# Altium schematic sheet MCU.SchDoc(MCU)
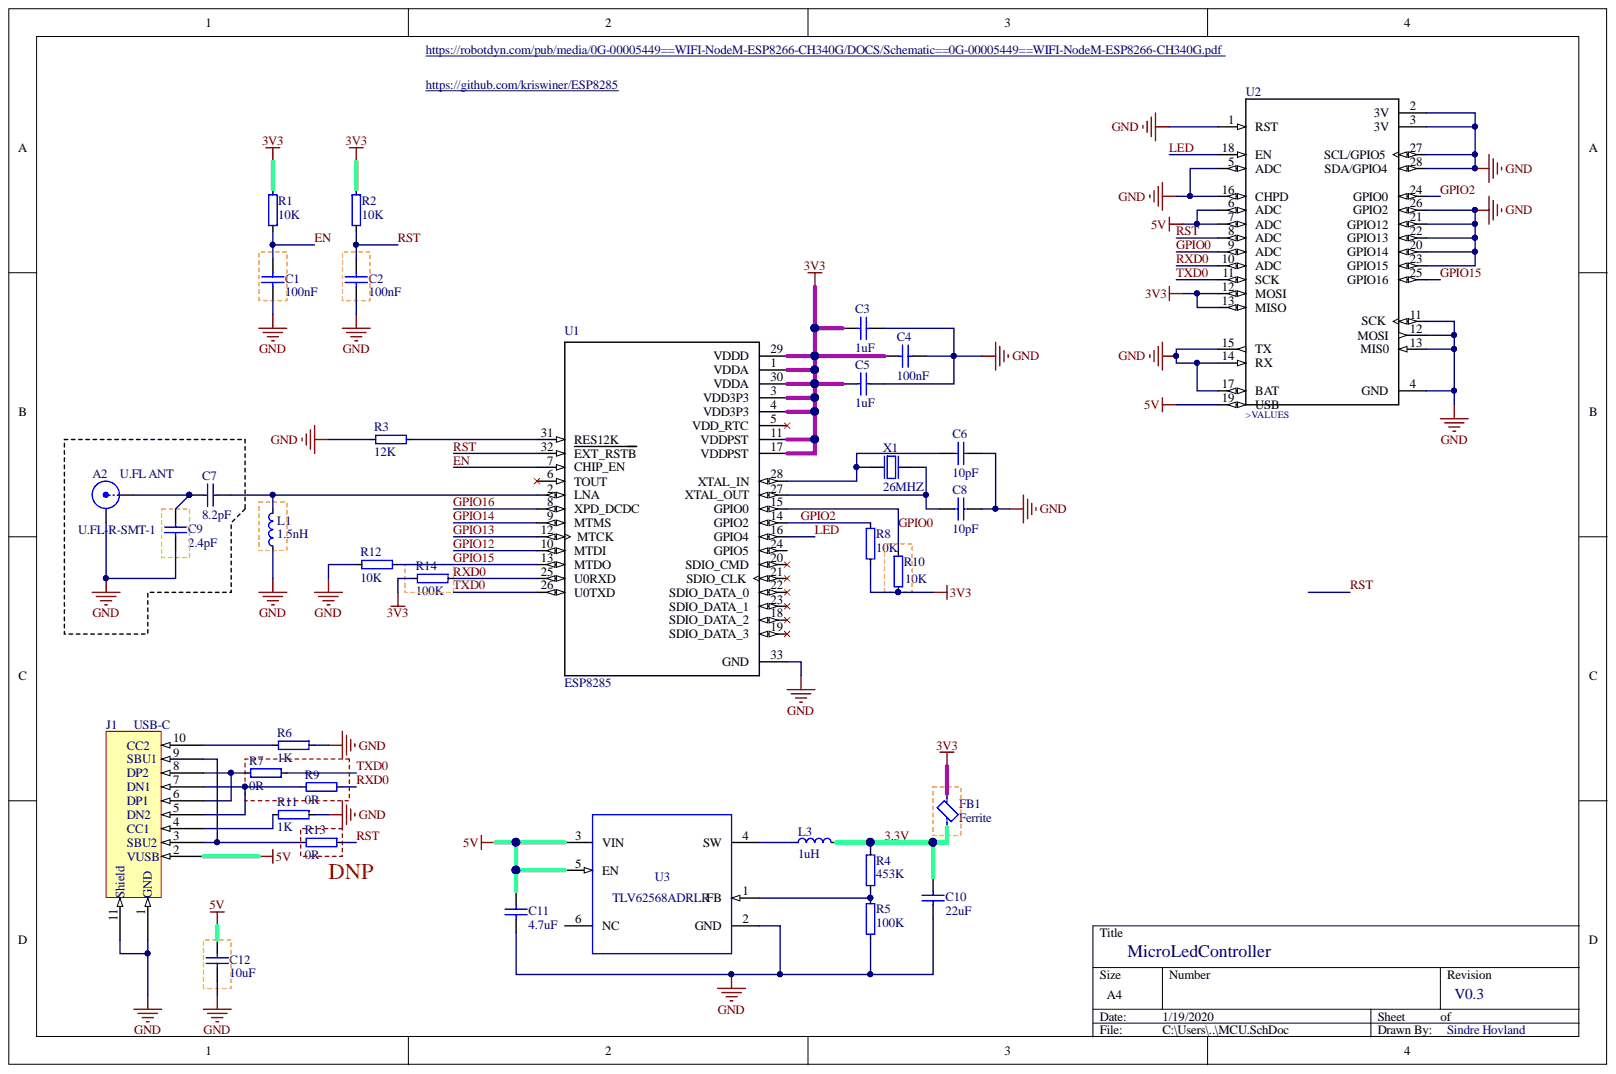
[diagram p0: MCU.SchDoc]

## Parts
A2  U.FL-R-SMT-1  [COAXIAL RF U.FL-R-SMT-1]
C1  100nF  [C0603]
C2  100nF  [C0603]
C3  1uF  [C0603]
C4  100nF  [C0603]
C5  1uF  [C0603]
C6  10pF  [C0603]
C7  8.2pF  [CAPC1608X06L]
C8  10pF  [C0603]
C9  2.4pF  [CAPC1608X06L]
C10  22uF  [C0603]
C11  4.7uF  [C0603]
C12  10uF  [C0603]
FB1  Ferrite  [IND0603]
J1  USB-C  [TYPE-C-31-M-12]
L1  1.5nH  [IND0603]
L3  1uH  [IND0603]
R1  10K  [RES0603R]
R2  10K  [RES0603R]
R3  12K  [RES0603R]
R4  453K  [RES0603R]
R5  100K  [RES0603R]
R6  1K  [RES0603R]
R7  0R  [RES0603R]
R8  10K  [RES0603R]
R9  0R  [RES0603R]
R10  10K  [RES0603R]
R11  1K  value 0R  [RES0603R]
R12  10K  [RES0603R]
R13  0R  [RES0603R]
R14  100K  [RES0603R]
U1  ESP8285  [*QFN50P500X500X80-33N]
U2  2821  [*XCVR_2821]  (value not drawn)
U3  TLV62568ADRLR  [DRL0006A]
X1  26MHZ  [BT-XTAL_2016]

## Nets
3V3: C3.2 C4.2 C5.2 FB1.1 R1.2 R2.2 R8.1 R10.1 R14.1 U1.1(VDDA) U1.3(VDD3P3) U1.4(VDD3P3) U1.11(VDDPST) U1.17(VDDPST) U1.29(VDDD) U1.30(VDDA) U2.12 U2.13
5V: C11.2 C12.2 J1.2(VUSB) U2.6(ADC) U2.7(ADC) U2.19(USB) U3.3(VIN) U3.5(EN)
GND: A2.2 C1.1 C2.1 C3.1 C4.1 C5.1 C6.1 C8.1 C9.1 C10.1 C11.1 C12.1 J1.1(GND) J1.11(Shield) L1.1 R3.1 R5.1 R6.2 R11.2 R12.1 U1.33(GND) U2.1(RST) U2.2(3V) U2.3(3V) U2.4(GND) U2.5(ADC) U2.11 U2.12 U2.13 U2.14(RX) U2.15(TX) U2.16(CHPD) U2.17(BAT) U2.20(GPIO14) U2.21(GPIO12) U2.22(GPIO13) U2.23(GPIO15) U2.26(GPIO2) U2.27(SCL/GPIO5) U2.28(SDA/GPIO4) U3.2(GND) X1.2 X1.4
3.3V: C10.2 FB1.2 L3.2 R4.2
EN: C1.2 R1.1 U1.7(CHIP_EN)
GPIO0: R10.2 U1.15(GPIO0) U2.9(ADC)
GPIO2: R8.2 U1.14(GPIO2) U2.24(GPIO0)
GPIO12: U1.10(MTDI)
GPIO13: U1.12(MTCK)
GPIO14: U1.9(MTMS)
GPIO15: R12.2 U1.13(MTDO) U2.25(GPIO16)
GPIO16: U1.8(XPD_DCDC)
LED: U1.16(GPIO4) U2.18(EN)
RST: C2.2 R2.1 R13.2 U1.32(EXT_RSTB) U2.8(ADC)
RXD0: R9.2 R14.2 U1.25(U0RXD) U2.10(ADC)
TXD0: R7.2 U1.26(U0TXD) U2.11
NetA2_1: A2.1 C7.2 C9.2
NetC6_2: C6.2 U1.28(XTAL_IN) X1.3
NetC7_1: C7.1 L1.2 U1.2(LNA)
NetC8_2: C8.2 U1.27(XTAL_OUT) X1.1
NetJ1_3: J1.3(SBU2) J1.9(SBU1) R13.1
NetJ1_4: J1.4(CC1) R11.1
NetJ1_5: J1.5(DN2) J1.7(DN1) R9.1
NetJ1_6: J1.6(DP1) J1.8(DP2) R7.1
NetJ1_10: J1.10(CC2) R6.1
NetL3_1: L3.1 U3.4(SW)
NetR3_2: R3.2 U1.31(RES12K)
NetR4_1: R4.1 R5.2 U3.1(FB)

Not connected: U1 5(VDD_RTC) 6(TOUT) 18(SDIO_DATA_2) 19(SDIO_DATA_3) 20(SDIO_CMD) 21(SDIO_CLK) 22(SDIO_DATA_0) 23(SDIO_DATA_1) 24(GPIO5); U3 6(NC)

## Library data of the parts
A2 = (no symbol name): ONN U.FL RCPT STR 50 OHM SMD; Part number IPS: N.A.; Manufacturer: Hirose Electric Co Ltd; Value: U.FL-R-SMT-1; Supplier Part Number 1: H11891DKR-ND; Supplier 1: Digi-Key; Published: 2018-05-07; Pin Count: 3; Part Number: U.FL-R-SMT-1; Manufacturer Part Number: U.FL-R-SMT-1; Library Name: NRF91.SCHLIB; LatestRevisionDate: 2018-05-07; Footprint Doc: COAXIAL RF U.FL-R-SMT-1; ComponentLink2Description: Supplier link; ComponentLink1URL: https://media.digikey.com/pdf/Data%20Sheets/Hirose%20PDFs/UFL%20Series.pdf; ComponentLink1Description: Datasheet; Component Kind: Standard; Artikkelnr Noca: N.A.; Publisher: Nordic Semiconductor
C1-C12 = CAP: Capacitor; Value: 100pF; Revision: July-2002: Re-released for DXP Platform.; Published: 8-Jun-2000; Library Name: MainLib.schlib; Component Kind: Standard; Publisher: Altium Limited
FB1 = (no symbol name): Ferrite Bead, 120 Ohm @ 100MHz, 500mA, 250 mOhm Max; Part number IPS: N.A.; Part Number: FB0402-120R@100M-500mA; Manufacturer Part Number: BLM15AG121SN1D; Manufacturer: Murata; Value: 120R/0.55A; Note: Chip EMIFIL Inductor Type Chip Ferrite Bead; Artikkelnr Noca: 33029317; Supplier Part Number 2: 151-5760; Supplier Part Number 1: 490-1005-1-ND; Supplier 2: Farnell; Supplier 1: Digi-Key; Published: 2011-11-14; Pin Count: 2; Library Name: MainLib.schlib; LatestRevisionDate: 2014-01-10; HelpURL: http://search.murata.co.jp/Ceramy/image/img/PDF/ENG/L0110S0100BLM15A_SN.pdf; Footprint Doc: 0402; ComponentLink2Description: Supplier link; ComponentLink1Description: Datasheet; Component Kind: Standard; Publisher: Nordic Semiconductor
L1 L3 = (no symbol name): Inductor; Part number IPS: N.A.; Part Number: FB0402-120R@100M-500mA; Manufacturer Part Number: BLM15AG121SN1D; Manufacturer: Murata; Value: 120R/0.55A; Note: Chip EMIFIL Inductor Type Chip Ferrite Bead; Artikkelnr Noca: 33029317; Supplier Part Number 2: 151-5760; Supplier Part Number 1: 490-1005-1-ND; Supplier 2: Farnell; Supplier 1: Digi-Key; Published: 2011-11-14; Pin Count: 2; Library Name: MainLib.schlib; LatestRevisionDate: 2014-01-10; HelpURL: http://search.murata.co.jp/Ceramy/image/img/PDF/ENG/L0110S0100BLM15A_SN.pdf; Footprint Doc: 0402; ComponentLink2Description: Supplier link; ComponentLink1Description: Datasheet; Component Kind: Standard; Publisher: Nordic Semiconductor
R1-R6 R8 R10 R12 R14 = (no symbol name): Resistor, ±5%, 0.05W; Part number IPS: 00000003245; Part Number: R0201-0R; Value: 0R; Note: Chip Res 5%; Artikkelnr Noca: N.A.; Published: 2014-11-13; Pin Count: 2; Library Name: MainLib.schlib; LatestRevisionDate: 2017-11-09; Footprint Doc: 0201; ComponentLink2Description: Supplier link; ComponentLink1Description: Datasheet; Component Kind: Standard; Publisher: Nordic Semiconductor
R7 R9 R11 R13 = (no symbol name): Resistor, ±5%, 0.05W; Part number IPS: 00000003245; Part Number: R0201-0R; Note: Chip Res 5%; Artikkelnr Noca: N.A.; Published: 2014-11-13; Pin Count: 2; Library Name: MainLib.schlib; LatestRevisionDate: 2017-11-09; Footprint Doc: 0201; ComponentLink2Description: Supplier link; ComponentLink1Description: Datasheet; Component Kind: Standard; Publisher: Nordic Semiconductor
  R7 R9 R13: Value: 0R
U1 = ESP8266EX: MF: Espressif Systems; SnapEDA_Link: https://www.snapeda.com/parts/ESP8266EX/Espressif%20Systems/view-part/555861/?ref=snap; Price: None; Package: VFQFN-32 Espressif Systems; MP: ESP8266EX; Library Name: ESP8266EX.SchLib; Description: ESP8266EX; ComponentLink1URL: https://www.espressif.com/sites/default/files/documentation/0a-esp8285_datasheet_en.pdf; ComponentLink1Description: datasheet; Component Kind: Standard; Availability: Unavailable
U2 = 2821: Adafruit Feather HUZZAH with ESP8266 WiFi; MF: Adafruit Industries; SnapEDA_Link: https://www.snapeda.com/parts/2821/Adafruit%20Industries%20LLC/view-part/?ref=snap; Price: None; Package: None; MP: 2821; Library Name: AdafruitFeather.SchLib; Component Kind: Standard; Availability: Unavailable
U3 = TLV62568ADRLR: Manufacturer Name: Texas Instruments; Value: N/A; PackageReference: TLV62568ADRLR; Manufacturer Part Number: TLV62568ADRLR; Library Name: TI WEBENCH; Description: TLV62568ADRLR; Component Kind: Standard
X1 = (no symbol name): X201626MOD4SI; Part number IPS: N.A.; Part Number: XTAL_SMD2016_32MHz_8pF_Golledge; Manufacturer Part Number: MP06003; Manufacturer: Golledge; Value: 32MHz; Note: XTAL SMD 32.000MHz; Artikkelnr Noca: 22010050; Supplier 1: Link Nordic; Published: 2016-11-02; Pin Count: 4; Library Name: NRF91.SCHLIB; LatestRevisionDate: 2016-11-02; HelpURL: \\hizz\pro\app9717\Datasheet\crystals\Golledge\mp06003.pdf; Footprint Doc: XTAL_2016; ComponentLink2Description: Supplier link; ComponentLink1Description: Datasheet; Component Kind: Standard; Publisher: Nordic Semiconductor
J1 = USB-C: Component Kind: Standard; Library Name: AdafruitFeather.SchLib
